# Altium schematic sheet nrf21540_qdaa_var1.SchDoc
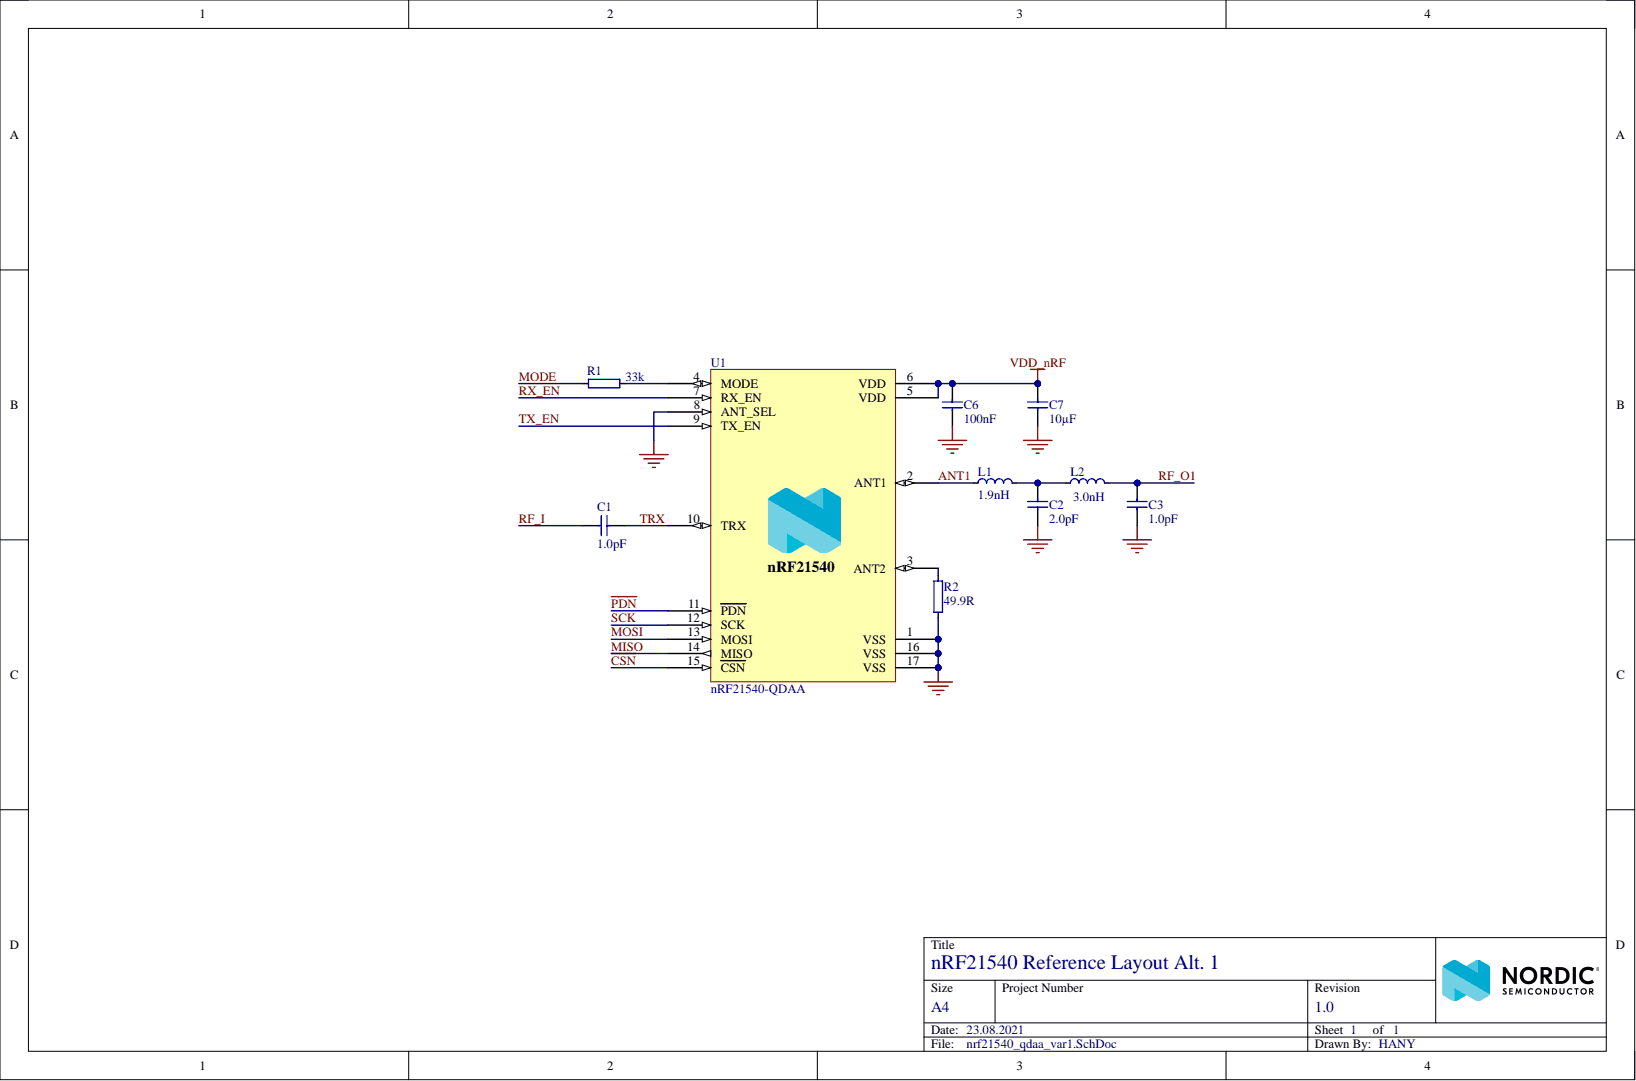
[diagram p0: nrf21540_qdaa_var1.SchDoc]

## Parts
C1  1.0pF  [CAPC0603X03L_C]
C2  2.0pF  [CAPC0603X03L_C]
C3  1.0pF  [CAPC0603X03L_C]
C6  100nF  [CAPC0603X03L_C]
C7  10µF  [CAPC1608X06L]
L1  1.9nH  [INDC0603X03L_C]
L2  3.0nH  [INDC0603X03L_C]
R1  33k  [RESC0603X03L_C]
R2  49.9R  [RESC0603X03L_C]
U1  nRF21540-QDAA  [QFN65P400X400X65_HS-17N]

## Nets
GND: C2.1 C3.1 C6.1 C7.1 R2.2 U1.1(VSS) U1.8(ANT_SEL) U1.16(VSS) U1.17(VSS)
VDD_nRF: C6.2 C7.2 U1.5(VDD) U1.6(VDD)
ANT1: L1.1 U1.2(ANT1)
~{CSN}: U1.15(CSN)
MISO: U1.14(MISO)
MODE: R1.1
MOSI: U1.13(MOSI)
~{PDN}: U1.11(PDN)
RF_I: C1.1
RF_O1: C3.2 L2.2
RX_EN: U1.7(RX_EN)
SCK: U1.12(SCK)
TRX: C1.2 U1.10(TRX)
TX_EN: U1.9(TX_EN)
NetC2_2: C2.2 L1.2 L2.1
NetR1_2: R1.2 U1.4(MODE)
NetR2_1: R2.1 U1.3(ANT2)

## Library data of the parts
C1 C3 = C0201-1.0p: Capacitor, NP0, ±0.05pF, 50V; Database Table Name: Parts$; Pad Count: 2; Component Kind: Standard; Footprint Doc: 0201; Library Name: AltiumLib.DbLib; Note: 50V; Part Number: C0201-1.0p; Value: 1.0pF; Author: rsk
C2 = C0201-2.0p-HQ-Murata: Capacitor, NP0, ±0.05pF, 25V; Database Table Name: Parts$; Pad Count: 2; Component Kind: Standard; Footprint Doc: 0201; Library Name: AltiumLib.DbLib; Note: 25V; Part Number: C0201-2.0p-HQ-Murata; Value: 2.0pF; Author: rsk
C6 = C0201-100n: Capacitor, X5R, ±10%; Database Table Name: Parts$; Note: 16V; Pad Count: 2; Component Kind: Standard; Footprint Doc: 0201; Library Name: AltiumLib.DbLib; Part Number: C0201-100n; Value: 100nF; Author: rsk
C7 = C0603-10µ: Capacitor, X5R, ±20%; Database Table Name: Parts$; Note: 6.3V; Pad Count: 2; Component Kind: Standard; Footprint Doc: 0603; Library Name: AltiumLib.DbLib; Part Number: C0603-10µ; Value: 10µF; Author: rsk
L1 = L0201-1n9-HQ-Murata: Inductor, 600mA, ±0.1ｎH, 120mOhm; Database Table Name: Parts$; Note: -55°C ~ 125°C; Pad Count: 2; Component Kind: Standard; Footprint Doc: 0201; Library Name: AltiumLib.DbLib; Part Number: L0201-1n9-HQ-Murata; Value: 1.9nH; Author: rsk
L2 = L0201-3n0-HQ-Murata: Inductor, 600mA, ±0.1ｎH, 120mOhm; Database Table Name: Parts$; Note: -55°C ~ 125°C; Pad Count: 2; Component Kind: Standard; Footprint Doc: 0201; Library Name: AltiumLib.DbLib; Part Number: L0201-3n0-HQ-Murata; Value: 3.0nH; Author: rsk
R1 = R0201-33k: Resistor, ±1%, 0.05W; Database Table Name: Parts$; Note: Chip Res 1%; Pad Count: 2; Component Kind: Standard; Footprint Doc: 0201; Library Name: AltiumLib.DbLib; Part Number: R0201-33k; Value: 33k; Author: rsk
R2 = R0201-49R9: Resistor, ±1%, 0.05W; Database Table Name: Parts$; Note: Chip Res 1%; Pad Count: 2; Component Kind: Standard; Footprint Doc: 0201; Library Name: AltiumLib.DbLib; Part Number: R0201-49R9; Value: 49.9R; Author: getr
U1 = nRF21540-QDAA: Radio front-end / range extender for 2.4GHz; Database Table Name: Parts$; Note: N.A.; Pad Count: 16; Component Kind: Standard; Footprint Doc: QFN-16; Library Name: AltiumLib.DbLib; Part Number: nRF21540-QDAA; Value: nRF21540-QDAA; Author: rsk
